# Altium schematic sheet FPGA_IO.SchDoc(U_FPGA_IO)
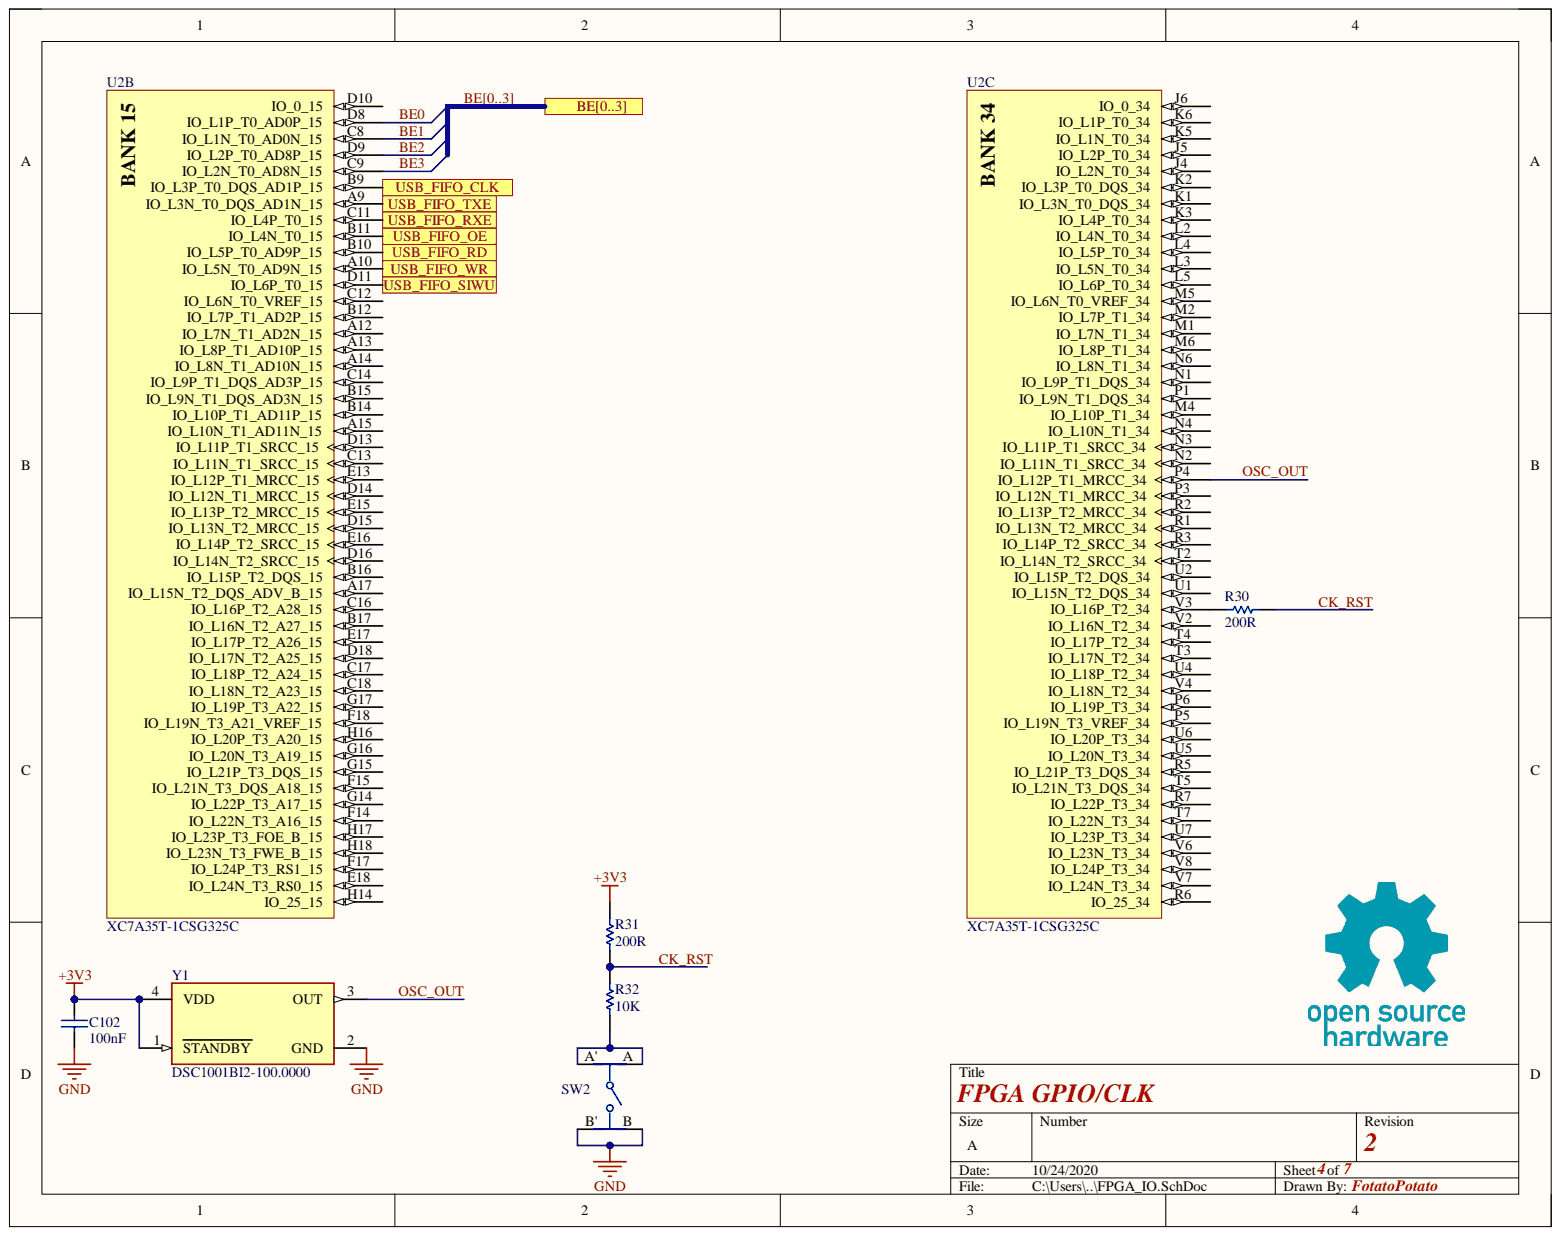
[diagram p0: FPGA_IO.SchDoc]

## Parts
C102  100nF  [CAPC1005X56X25LL10T15]
R30  200R  [RESC0402(1005)_M]
R31  200R  [RESC0402(1005)_M]
R32  10K  [RESC1005X40X25NL05T05]
SW2  EVQ-Q2U03W  [FP-EVQQ2-MFG]  (value not drawn)
U2  XC7A35T-1CSG325C  [CSG325]  (parts B,C)
Y1  DSC1001BI2-100.0000  [FP-CDFN2520-4LD-PL-1-MFG]

## Ports
BE0, BE1, BE2, BE3, BE[0..3], USB_FIFO_CLK, USB_FIFO_OE, USB_FIFO_RD, USB_FIFO_RXE, USB_FIFO_SIWU, USB_FIFO_TXE, USB_FIFO_WR

## Nets
+3V3: C102.2 R31.2 Y1.1(STANDBY) Y1.4(VDD)
GND: C102.1 SW2.B SW2.B' Y1.2(GND)
BE0: U2.D8(IO_L1P_T0_AD0P_15) ; port BE[0..3]  [also labelled BE]
BE1: U2.C8(IO_L1N_T0_AD0N_15) ; port BE[0..3]  [also labelled BE]
BE2: U2.D9(IO_L2P_T0_AD8P_15) ; port BE[0..3]  [also labelled BE]
BE3: U2.C9(IO_L2N_T0_AD8N_15) ; port BE[0..3]  [also labelled BE]
CK_RST: R30.1 R31.1 R32.2
OSC_OUT: U2.P4(IO_L12P_T1_MRCC_34) Y1.3(OUT)
USB_FIFO_CLK: U2.B9(IO_L3P_T0_DQS_AD1P_15) ; port USB_FIFO_CLK
USB_FIFO_OE: U2.B11(IO_L4N_T0_15) ; port USB_FIFO_OE
USB_FIFO_RD: U2.B10(IO_L5P_T0_AD9P_15) ; port USB_FIFO_RD
USB_FIFO_RXE: U2.C11(IO_L4P_T0_15) ; port USB_FIFO_RXE
USB_FIFO_SIWU: U2.D11(IO_L6P_T0_15) ; port USB_FIFO_SIWU
USB_FIFO_TXE: U2.A9(IO_L3N_T0_DQS_AD1N_15) ; port USB_FIFO_TXE
USB_FIFO_WR: U2.A10(IO_L5N_T0_AD9N_15) ; port USB_FIFO_WR
NetR30_2: R30.2 U2.V3(IO_L16P_T2_34)
NetR32_1: R32.1 SW2.A SW2.A'

Not connected: U2 A12(IO_L7N_T1_AD2N_15) A13(IO_L8P_T1_AD10P_15) A14(IO_L8N_T1_AD10N_15) A15(IO_L10N_T1_AD11N_15) A17(IO_L15N_T2_DQS_ADV_B_15) B12(IO_L7P_T1_AD2P_15) B14(IO_L10P_T1_AD11P_15) B15(IO_L9N_T1_DQS_AD3N_15) B16(IO_L15P_T2_DQS_15) B17(IO_L16N_T2_A27_15) C12(IO_L6N_T0_VREF_15) C13(IO_L11N_T1_SRCC_15) C14(IO_L9P_T1_DQS_AD3P_15) C16(IO_L16P_T2_A28_15) C17(IO_L18P_T2_A24_15) C18(IO_L18N_T2_A23_15) D10(IO_0_15) D13(IO_L11P_T1_SRCC_15) D14(IO_L12N_T1_MRCC_15) D15(IO_L13N_T2_MRCC_15) D16(IO_L14N_T2_SRCC_15) D18(IO_L17N_T2_A25_15) E13(IO_L12P_T1_MRCC_15) E15(IO_L13P_T2_MRCC_15) E16(IO_L14P_T2_SRCC_15) E17(IO_L17P_T2_A26_15) E18(IO_L24N_T3_RS0_15) F14(IO_L22N_T3_A16_15) F15(IO_L21N_T3_DQS_A18_15) F17(IO_L24P_T3_RS1_15) F18(IO_L19N_T3_A21_VREF_15) G14(IO_L22P_T3_A17_15) G15(IO_L21P_T3_DQS_15) G16(IO_L20N_T3_A19_15) G17(IO_L19P_T3_A22_15) H14(IO_25_15) H16(IO_L20P_T3_A20_15) H17(IO_L23P_T3_FOE_B_15) H18(IO_L23N_T3_FWE_B_15) J4(IO_L2N_T0_34) J5(IO_L2P_T0_34) J6(IO_0_34) K1(IO_L3N_T0_DQS_34) K2(IO_L3P_T0_DQS_34) K3(IO_L4P_T0_34) K5(IO_L1N_T0_34) K6(IO_L1P_T0_34) L2(IO_L4N_T0_34) L3(IO_L5N_T0_34) L4(IO_L5P_T0_34) L5(IO_L6P_T0_34) M1(IO_L7N_T1_34) M2(IO_L7P_T1_34) M4(IO_L10P_T1_34) M5(IO_L6N_T0_VREF_34) M6(IO_L8P_T1_34) N1(IO_L9P_T1_DQS_34) N2(IO_L11N_T1_SRCC_34) N3(IO_L11P_T1_SRCC_34) N4(IO_L10N_T1_34) N6(IO_L8N_T1_34) P1(IO_L9N_T1_DQS_34) P3(IO_L12N_T1_MRCC_34) P5(IO_L19N_T3_VREF_34) P6(IO_L19P_T3_34) R1(IO_L13N_T2_MRCC_34) R2(IO_L13P_T2_MRCC_34) R3(IO_L14P_T2_SRCC_34) R5(IO_L21P_T3_DQS_34) R6(IO_25_34) R7(IO_L22P_T3_34) T2(IO_L14N_T2_SRCC_34) T3(IO_L17N_T2_34) T4(IO_L17P_T2_34) T5(IO_L21N_T3_DQS_34) T7(IO_L22N_T3_34) U1(IO_L15N_T2_DQS_34) U2(IO_L15P_T2_DQS_34) U4(IO_L18P_T2_34) U5(IO_L20N_T3_34) U6(IO_L20P_T3_34) U7(IO_L23P_T3_34) V2(IO_L16N_T2_34) V4(IO_L18N_T2_34) V6(IO_L23N_T3_34) V7(IO_L24N_T3_34) V8(IO_L24P_T3_34)

## Library data of the parts
C102 = CMP-2008-02743-1: Manufacturer: AVX Interconnect / Elco; Part Number: 04026D104KAT2A; Component Kind: Standard; Library Name: Altium Content Vault
R30 R31 = CMP-1011-00429-1: 200R 0.063W 1% 0402 (1005 Metric)  SMD; Case-EIA: 0402; Case-Metric: 1005; Value: 200.00 Ohm; Component Kind: Standard; Library Name: Altium Content Vault; Power: 0.063 W; Technology: SMT; Tolerance: 1 %
R32 = CMP-2002-08135-1: Manufacturer: Vishay Dale; Part Number: CRCW040210K0FKED; Component Kind: Standard; Library Name: Altium Content Vault
SW2 = CMP-05618-000012-1: SWITCH TACTILE SPST-NO 0.02A 15V; Manufacturer: Panasonic; Contact Current Rating: 20mA; Contact Resistance: 100mΩ; Electromechanical Life: 200000Cycles; Operating Force: 2.6N; Automotive: No; Category: Switch; Component Kind: Standard; ComponentLink2Description: Datasheet; ComponentLink2URL: https://industrial.panasonic.com/cdbs/www-data/pdf/ATK0000/ATK0000C360.pdf; Device Class L1: Electromechanical; Device Class L2: Switches; Device Class L3: Tactile Switches; Digikey Description: SWITCH TACTILE SPST-NO 0.02A 15V; Height: 3.3mm; Lead Free: Yes; Library Name: Altium Content Vault; Manufacturer Part Number: EVQ-Q2U03W; Mount: Surface Mount; Mouser Description: Tactile Switches 2.6NF 6.5x6.0x3.1mm; Octopart: https://octopart.com/evq-q2u03w-panasonic-1404553; Package: EVQQ2; RoHS: TRUE; Temperature: -40°C to +85°C; Temperature Range High: +85°C; Temperature Range Low: -40°C; Throw Configuration: SPST-NO; Voltage Rating Dc: 15V
U2 = CMP-0383-00016-1: Artix-7 FPGA, 150 User I/Os, 4 GTP, 324-Ball BGA, Speed Grade 1, Commercial Grade, Pb-Free; Manufacturer: Xilinx; Available User I/O: 150; CMTs: 5; GTP: 4; Logic Cells: 33280; Speed Grades: 1; Total Block RAM: 1800 Kb; Component Kind: Standard; ComponentLink1Description: Manufacturer URL; ComponentLink1URL: http://www.xilinx.com/; ComponentLink2Description: Datasheet; ComponentLink2URL: http://www.xilinx.com/support/documentation/data_sheets/ds180_7Series_Overview.pdf; ComponentLink3Description: Pinout; ComponentLink3URL: http://www.xilinx.com/support/documentation/user_guides/ug475_7Series_Pkg_Pinout.pdf; ComponentLink4Description: Package Specification; ComponentLink4URL: http://www.xilinx.com/support/documentation/user_guides/ug475_7Series_Pkg_Pinout.pdf; DatasheetVersion: Rev. 1.15; Library Name: Altium Content Vault; Maximum Distributed RAM: 400 Kb; Mounting Technology: Surface Mount; Operating Temperature Range: Commercial; PackageDescription: 324-Ball Wire-Bond Chip-Scale BGA, Body 15 x 15 mm, Pitch 0.8 mm; PackageReference: CSG-325; PackageVersion: Rev. 1.11; PartNumber: XC7A35T-1CSG325C
Y1 = CMP-06255-000005-1: MEMS OSC XO 25.0000MHZ CMOS SMD; Manufacturer: Microchip Technology; Manufacturer Part Number: DSC1001DI1-025.0000T; Automotive Grade: Grade 3; Frequency: 25MHz; Max Junction Temp: +150°C; Max Supply Voltage: 3.6V; Min Supply Voltage: 1.7V; Stability: 50ppm; Ambient Temperature Range High: +85°C; Ambient Temperature Range Low: -40°C; Automotive: Yes; Category: Crys; Component Kind: Standard; ComponentLink2Description: Datasheet; ComponentLink2URL: http://ww1.microchip.com/downloads/en/DeviceDoc/20005529B.pdf; Device Class L1: Passive Components; Device Class L2: Crystals / Oscillators; Device Class L3: Oscillators; Digikey Description: MEMS OSC XO 25.0000MHZ CMOS SMD; Height: 0.9mm; Lead Free: Yes; Library Name: Altium Content Vault; Octopart: https://octopart.com/dsc1001di1-025.0000t-microchip-66798976; Package: CDFN2520-4LD-PL-1; RoHS: TRUE; Series: DSC1001; Temperature: -40°C to +85°C; Temperature Range High: +85°C; Temperature Range Low: -40°C
